# Altium schematic sheet Base_USB_Camera.SchDoc
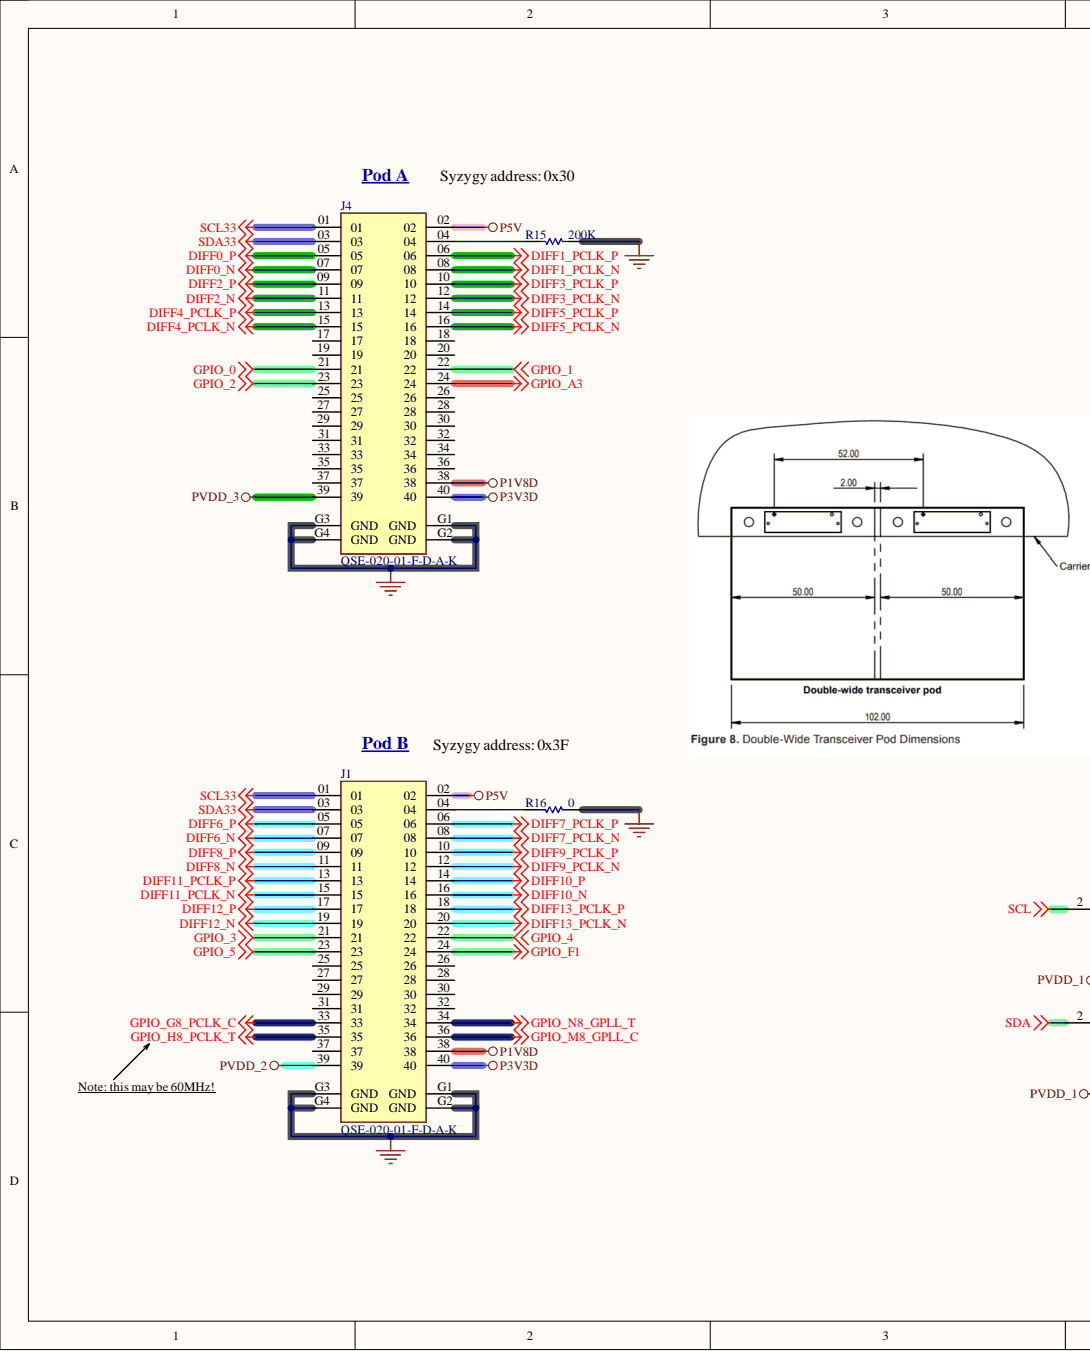
[diagram p0: Base_USB_Camera.SchDoc, left]
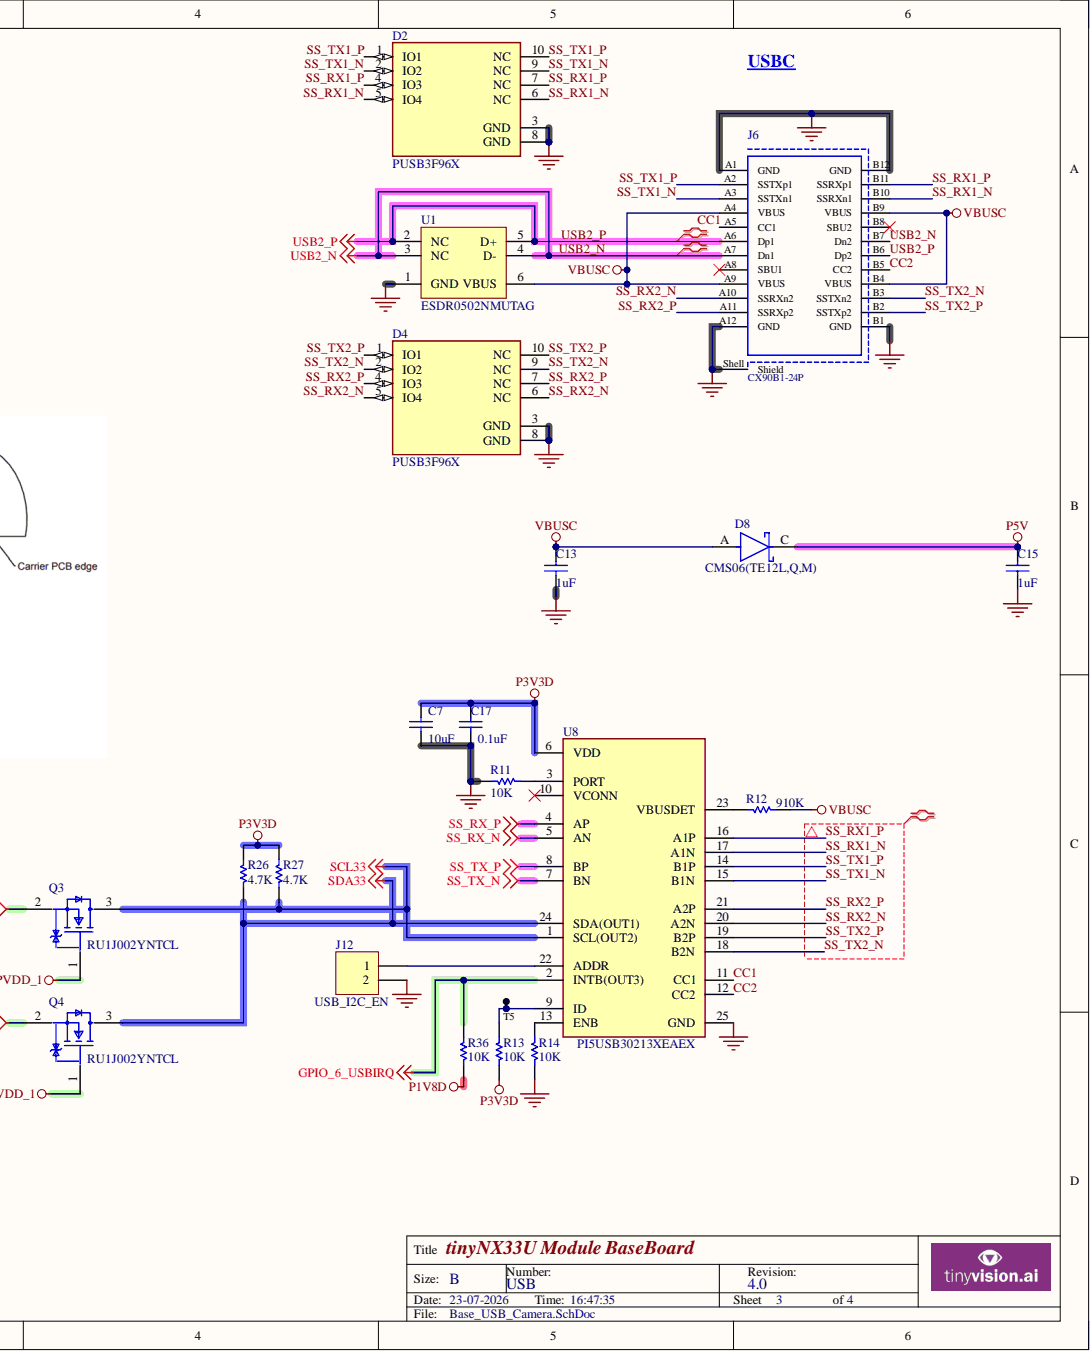
[diagram p1: Base_USB_Camera.SchDoc, right]

## Parts
C7  10uF  [CAPC1005X55USR]
C13  1uF  [CAPC1005X55USR]
C15  1uF  [CAPC1005X55USR]
C17  0.1uF  [CAPC1005X55USR]
D2  PUSB3F96X  [DFN2510A-10]
D4  PUSB3F96X  [DFN2510A-10]
D8  CMS06(TE12L,Q,M)  [SOD-128]
J1  QSE-020-01-F-D-A-K  [SAMTEC_QSE-020-01-XXX-D-A-L]
J4  QSE-020-01-F-D-A-K  [SAMTEC_QSE-020-01-XXX-D-A-L]
J6  CX90B1-24P  [HRS_CX90B1-24P]
J12  Content: USB_I2C_EN  [HDR2X1_MALE_2.54MM]  (hidden comment: Header 2X1_2.54MM)
Q3  RU1J002YNTCL  [FP-UMT3F-MFG]
Q4  RU1J002YNTCL  [FP-UMT3F-MFG]
R11  10K  [RESC1005X40USR]
R12  910K  [RESC1005X40USR]
R13  10K  [RESC1005X40USR]
R14  10K  [RESC1005X40USR]
R15  200K  [RESC1005X40USR]
R16  0  [RESC1005X40USR]
R26  4.7K  [RESC1005X40USR]
R27  4.7K  [RESC1005X40USR]
R36  10K  [RESC1005X40USR]
T5  TP_S  [TP_TH]  (value not drawn)  (no BOM)
U1  ESDR0502NMUTAG  [ONSC-UDFN-6-517AA-01_V]
U8  PI5USB30213XEAEX  [QFN40P400X200X50-25N]  (value not drawn)

## Nets
DIFF0_N: J4.07
DIFF0_P: J4.05
DIFF1_PCLK_N: J4.08
DIFF1_PCLK_P: J4.06
DIFF2_N: J4.11
DIFF2_P: J4.09
DIFF3_PCLK_N: J4.12
DIFF3_PCLK_P: J4.10
DIFF4_PCLK_N: J4.15
DIFF4_PCLK_P: J4.13
DIFF5_PCLK_N: J4.16
DIFF5_PCLK_P: J4.14
DIFF6_N: J1.07
DIFF6_P: J1.05
DIFF7_PCLK_N: J1.08
DIFF7_PCLK_P: J1.06
DIFF8_N: J1.11
DIFF8_P: J1.09
DIFF9_PCLK_N: J1.12
DIFF9_PCLK_P: J1.10
DIFF10_N: J1.16
DIFF10_P: J1.14
DIFF11_PCLK_N: J1.15
DIFF11_PCLK_P: J1.13
DIFF12_N: J1.19
DIFF12_P: J1.17
DIFF13_PCLK_N: J1.20
DIFF13_PCLK_P: J1.18
GND: C7.1 C13.1 C15.1 C17.1 D2.3(GND) D2.8(GND) D4.3(GND) D4.8(GND) J1.G1(GND) J1.G2(GND) J1.G3(GND) J1.G4(GND) J4.G1(GND) J4.G2(GND) J4.G3(GND) J4.G4(GND) J6.A1(GND) J6.A12(GND) J6.B1(GND) J6.B12(GND) J6.Shell(Shield) J12.2 R11.1 R14.2 R15.1 R16.1 U1.1(GND) U8.25(GND)
GPIO_0: J4.21
GPIO_1: J4.22
GPIO_2: J4.23
GPIO_3: J1.21
GPIO_4: J1.22
GPIO_5: J1.23
GPIO_6_USBIRQ: R36.2 U8.2(INTB(OUT3))
GPIO_A3: J4.24
GPIO_F1: J1.24
GPIO_G8_PCLK_C: J1.33
GPIO_H8_PCLK_T: J1.35
GPIO_M8_GPLL_C: J1.36
GPIO_N8_GPLL_T: J1.34
P1V8D: J1.38 J4.38 R36.1
P3V3D: C7.2 C17.2 J1.40 J4.40 R13.1 R26.1 R27.1 U8.6(VDD)
P5V: C15.2 D8.C J1.02 J4.02
PVDD_1: Q3.1 Q4.1
PVDD_2: J1.39
PVDD_3: J4.39
SCL: Q3.2
SCL33: J1.01 J4.01 Q3.3 R27.2 U8.1(SCL(OUT2))
SDA: Q4.2
SDA33: J1.03 J4.03 Q4.3 R26.2 U8.24(SDA(OUT1))
SS_RX_N: U8.5(AN)
SS_RX_P: U8.4(AP)
SS_TX_N: U8.7(BN)
SS_TX_P: U8.8(BP)
VBUSC: C13.2 D8.A J6.A4(VBUS) J6.A9(VBUS) J6.B4(VBUS) J6.B9(VBUS) R12.2 U1.6(VBUS)
CC1: J6.A5(CC1) U8.11(CC1)
CC2: J6.B5(CC2) U8.12(CC2)
SS_RX1_N: D2.5(IO4) D2.6(NC) J6.B10(SSRXn1) U8.17(A1N)
SS_RX1_P: D2.4(IO3) D2.7(NC) J6.B11(SSRXp1) U8.16(A1P)
SS_RX2_N: D4.5(IO4) D4.6(NC) J6.A10(SSRXn2) U8.20(A2N)
SS_RX2_P: D4.4(IO3) D4.7(NC) J6.A11(SSRXp2) U8.21(A2P)
SS_TX1_N: D2.2(IO2) D2.9(NC) J6.A3(SSTXn1) U8.15(B1N)
SS_TX1_P: D2.1(IO1) D2.10(NC) J6.A2(SSTXp1) U8.14(B1P)
SS_TX2_N: D4.2(IO2) D4.9(NC) J6.B3(SSTXn2) U8.18(B2N)
SS_TX2_P: D4.1(IO1) D4.10(NC) J6.B2(SSTXp2) U8.19(B2P)
USB2_N: J6.A7(Dn1) J6.B7(Dn2) U1.3(NC) U1.4(D-)
USB2_P: J6.A6(Dp1) J6.B6(Dp2) U1.2(NC) U1.5(D+)
NetJ1_04: J1.04 R16.2
NetJ4_04: J4.04 R15.2
NetJ12_1: J12.1 U8.22(ADDR)
NetR11_2: R11.2 U8.3(PORT)
NetR12_1: R12.1 U8.23(VBUSDET)
NetR13_2: R13.2 T5.1 U8.9(ID)
NetR14_1: R14.1 U8.13(ENB)

Not connected: J1 25 26 27 28 29 30 31 32 37; J4 17 18 19 20 25 26 27 28 29 30 31 32 33 34 35 36 37; J6 A8(SBU1) B8(SBU2); U8 10(VCONN)

## Library data of the parts
C7 = Cap_10uF_X5R_20%_10V_0402: CAP CER 10UF 10V X5R 0402; Manufacturer: Samsung Electro-Mechanics; Manufacturer Part Number: CL05A106MP5NUNC; Part #: E-CAP-042; Component Kind: Standard; ComponentLink1Description: New link; Height: 0.55mm; Package: 0402; Supplier 1: Digi-Key; Supplier Part Number 1: 1276-1450-1-ND; value: 10uF; Library Name: tinyCLUNX33_Compute.SCHLIB
C13 C15 = CAP CER 1UF 25V X5R 0402: Manufacturer: Murata Electronics; Manufacturer Part Number: GRM155R61E105MA12D; Part #: E-CAP-042; Component Kind: Standard; ComponentLink1Description: New link; Description: CAP CER 1UF 25V X5R 0402; Height: 0.55mm; Package: 0402; Supplier 1: Digi-Key; Supplier Part Number 1: 490-10018-1-ND; value: 1uF; Library Name: Tiger_Ti180.SCHLIB
C17 = CAP CER 0.1UF 16V X7R 0402: Manufacturer: TDK Corporation; Manufacturer Part Number: CGA2B1X7R1C104K050BC; Component Kind: Standard; Description: CAP CER 0.1UF 16V X7R 0402; Height: 0.55mm; Library Name: NX Module baseboard.SCHLIB; Package: 0402; Supplier 1: Digi-Key; Supplier Part Number 1: 445-5613-2-ND; value: 0.1uF
D2 D4 = PUSB3F96X: TVS DIODE 5.5VWM 4.6VC DFN2510A; Manufacturer: Nexperia USA Inc.; Interface: USB; Component Kind: Standard; Manufacturer Part Number: PUSB3F96X; Supplier 1: Digi-Key; Supplier Part Number 1: 1727-2030-1-ND; Library Name: Tiger_Ti180.SCHLIB
D8 = D_CMS06(TE12L,Q,M): Rectifier Diode Schottky 30V 2A 2-Pin M-FLAT T/R; Manufacturer: Toshiba; Element Configuration: Single; Forward Voltage: 370mV; Max Reverse Current: 3mA; Max Surge Current: 40A; Average Rectified Current: 2A; Category: Rectifier Diodes; Component Kind: Standard; ComponentLink1Description: https://datasheet.ciiva.com/916/dst-cms06-tde-en-1613-916722.pdf?src-supplier=Digi-Key; ComponentLink1URL: https://datasheet.ciiva.com/916/dst-cms06-tde-en-1613-916722.pdf?src-supplier=Digi-Key; ComponentLink2Description: https://datasheet.ciiva.com/916/dst-cms06-tde-en-1613-916723.pdf?src-supplier=Digi-Key; ComponentLink2URL: https://datasheet.ciiva.com/916/dst-cms06-tde-en-1613-916723.pdf?src-supplier=Digi-Key; ComponentLink3Description: https://datasheet.ciiva.com/8281/cms06-datasheet-en-20131101-8281819.pdf?src-supplier=Avnet; ComponentLink3URL: https://datasheet.ciiva.com/8281/cms06-datasheet-en-20131101-8281819.pdf?src-supplier=Avnet; Contact Plating: Tin,Silver; Forward Current: 2A; Manufacturer Part Number: CMS06(TE12L,Q,M); Max Operating Temperature: 125°C; Max Repetitive Reverse Voltage (Vrrm): 30V; Max Reverse Leakage Current: 3mA; Max Reverse Voltage (DC): 30V; Min Operating Temperature: -40°C; Mount: Surface Mount; Number of Pins: 2; Package Quantity: 1; Packaging: Tape and Reel; Peak Non-Repetitive Surge Current: 40A; Pricing 1: 1=0.56000, 10=0.48200, 100=0.35950, 500=0.28248, 1000=0.21828 (USD); Radiation Hardening: No; RoHS: No; RoHS Compliant: No; Series: -; Stock 1: 49976; Supplier 1: Digi-Key; Supplier Part Number 1: CMS06QMCT-ND; Library Name: Tiger_Ti180.SCHLIB
J1 J4 = QSE-020-01-F-D-A-K: Q Strip® High-Speed Ground Plane Header, 0.80mm Pitch; Manufacturer: Samtec; Manufacturer Part Number: QTE-020-01-F-D-A; Gender: Male; Mated Stacking Heights: 5mm; Number of Contacts: 40; Pitch: 0.031inch; Category: Board to Board Connectors; Component Kind: Standard; ComponentLink1Description: https://datasheet.ciiva.com/6924/qte-xxx-xx-x-d-xxx-mkt-6924765.pdf?src-supplier=Digi-Key; ComponentLink1URL: https://datasheet.ciiva.com/6924/qte-xxx-xx-x-d-xxx-mkt-6924765.pdf?src-supplier=Digi-Key; Contact Plating: Gold; Library Name: NX Module baseboard.SchLib; Mounting Technology: Surface Mount; Number of Positions: 40; Number of Rows: 2; Package Quantity: 1; Packaging: Tray; Pricing: 1=9.48000, 10=8.53000, 25=8.03560, 40=7.82950, 96=7.62344, 288=6.79931, 480=6.38725, 960=5.64550 (USD); RoHS: Yes; RoHS Compliant: Yes; Series: QStrip® QTE; Stock: 1329; Supplier 1: Digi-Key; Supplier Part Number 1: SAM8846-ND; Termination: Solder
J6 = USB 3.1_HRS_CX90B1-24P: CONN RCP USB3.1 TYPEC 24P SMD RA; Manufacturer: Hirose Electric Co Ltd; Number of Contacts: 24; Component Kind: Standard; ComponentLink1Description: https://www.mouser.com/ds/2/445/632723x00011-782157.pdf?src-supplier=Mouser; ComponentLink1URL: https://www.mouser.com/ds/2/445/632723x00011-782157.pdf?src-supplier=Mouser; ComponentLink2Description: https://katalog.we-online.de/em/datasheet/632723x00011.pdf?src-supplier=Digi-Key; ComponentLink2URL: https://katalog.we-online.de/em/datasheet/632723x00011.pdf?src-supplier=Digi-Key; Manufacturer Part Number: CX90B1-24P; Supplier 1: Digi-Key; Supplier Part Number 1: H125778CT-ND; Library Name: Tiger_Ti180.SCHLIB
J12 = Header 2X1_2.54MM: Conn Header Vert 2POS 2.54MM; Manufacturer: Sullins; Manufacturer Part Number: PRPC002SAAN-RC; Number of Positions: 2; Pitch: 0.1inch; Category: Connectors; Color: Black; Component Kind: Standard; ComponentLink1Description: https://datasheet.ciiva.com/741/xrxczzzsxxn-rc-st-11635-b-741243.pdf?src-supplier=Digi-Key; ComponentLink1URL: https://datasheet.ciiva.com/741/xrxczzzsxxn-rc-st-11635-b-741243.pdf?src-supplier=Digi-Key; Contact Mating Length: 0.23inch; Contact Plating: Gold; Contact Type: Male Pin; Library Name: NX Module baseboard.SCHLIB; Mounting Technology: Through Hole; Number of Rows: 1; Package Quantity: 1; Packaging: Bulk; Pricing: 1=0.08000, 10=0.07300, 25=0.05400, 50=0.04640, 100=0.04490, 250=0.03872, 500=0.03716 (USD); RoHS: Yes; RoHS Compliant: Yes; Series: -; Stock: 31528; Supplier 1: Digi-Key; Supplier Part Number 1: S1011EC-02-ND; Termination: Solder
Q3 Q4 = 1926738d861a973c8d722c53ec8fd09: MOSFET N-CH 50V 0.2A UMT3F; Manufacturer: Rohm; Continuous Drain Current: 200mA; Depletion Mode: False; Drain To Source Resistance: 1.6Ω; Gate To Source Voltage: 8V; Input Capacitace At Vds: 26pF @ 10V; Max Forward Diode Voltage: 1.2V; Max Junction Temp: +150°C; Number Of Channels: 1; Power Dissipation: 150mW; Pulse Drain Current: 800mA; Rthja Max: 833°C/W; Standoff Height: 0mm; Threshold Vgs Max: 0.8V; Threshold Vgs Min: 0.3V; Turn Off Delay Time: 17ns; Turn On Delay Time: 5ns; Automotive: No; Category: Trans; Component Kind: Standard; ComponentLink2Description: Datasheet; ComponentLink2URL: https://d1d2qsbl8m0m72.cloudfront.net/en/products/databook/datasheet/discrete/transistor/mosfet/ru1j002yn-e.pdf; Device Class L1: Discrete Semiconductors; Device Class L2: Transistors; Device Class L3: MOSFETs; Digikey Description: MOSFET N-CH 50V 0.2A UMT3F; Drain To Source Breakdown Voltage: 50V; Drain To Source Voltage: 50V; Height: 1.05mm; Lead Free: Yes; Library Name: rpi_adapter.SCHLIB; Manufacturer Part Number: RU1J002YNTCL; Mouser Description: MOSFET 0.9V Drive Nch MOSFET; Number Of N Channels: 1; Octopart: https://octopart.com/ru1j002yntcl-rohm-47197697; Package: UMT3F; RoHS: TRUE; Temperature: to +150°C; Temperature Range High: +150°C
R11 R13 R14 R36 = RES SMD 10K OHM 1% 1/16W 0402: Manufacturer: Samsung Electro-Mechanics; Manufacturer Part Number: RC1005F103CS; Component Kind: Standard; Description: RES SMD 10K OHM 1% 1/16W 0402; Height: 0.4mm; Library Name: NX Module baseboard.SCHLIB; Package: 0402; Supplier 1: Digi-Key; Supplier Part Number 1: 1276-3431-2-ND; value: 10K
R12 = RES 910K OHM 1% 1/16W 0402: Manufacturer: YAGEO; Manufacturer Part Number: RC0402FR-07910KL; Component Kind: Standard; Description: RES 910K OHM 1% 1/16W 0402; Height: 0.4mm; Library Name: NX Module baseboard.SCHLIB; Package: 0402; Supplier 1: Digi-Key; Supplier Part Number 1: YAG3257CT-ND; value: 910K
R15 = Res_10K_1%_0402: RES SMD 200K OHM 1% 1/16W 0402; Manufacturer: Stackpole Electronics Inc; Manufacturer Part Number: RMCF0402FT200K; Component Kind: Standard; Height: 0.4mm; Package: 0402; Supplier 1: Digi-Key; Supplier Part Number 1: RMCF0402FT200KTR-ND; value: 200K; Library Name: MCD_Temp_LBE.SchLib
R16 = RES 0 OHM JUMPER 1/20W 0201: RES 0 OHM JUMPER 1/16W 0402; Manufacturer: Yageo; Manufacturer Part Number: RC0402JR-070RL; Component Kind: Standard; Height: 0.4mm; Library Name: Smart Camera v2.SCHLIB; Package: 0402; Supplier 1: Digi-Key; Supplier Part Number 1: 311-0.0JRCT-ND; value: 0
R26 R27 = RES 4.7K OHM 1% 1/16W 0402: RES SMD 4.7K OHM 1% 1/16W 0402; Manufacturer: Stackpole Electronics Inc; Manufacturer Part Number: RMCF0402FT4K70; Component Kind: Standard; Height: 0.4mm; Package: 0402; Supplier 1: Digi-Key; Supplier Part Number 1: RMCF0402FT4K70TR-ND; value: 4.7K; Library Name: Tiger_Ti180.SCHLIB
T5 = TP_S: test point; Library Name: AiC.SCHLIB
U1 = ONSC-ESDR0502N-UDFN-6-517AA-01: TVS DIODE 5.5VWM 6UDFN; Manufacturer: ON Semiconductor; Component Kind: Standard; ComponentLink1Description: Manufacturer URL; ComponentLink1URL: http://www.onsemi.com/; ComponentLink2Description: Datasheet; ComponentLink2URL: http://www.onsemi.cn/pub_link/Collateral/ESDR0502N-D.PDF; ComponentLink3Description: Package Specification; ComponentLink3URL: http://www.onsemi.com/pub_link/Collateral/517AA.PDF; Manufacturer Part Number: ESDR0502NMUTAG; Supplier 1: Mouser; Supplier Part Number 1: 863-ESDR0502NMUTAG; Library Name: Tiger_Ti180.SCHLIB
U8 = PI5USB30213XEAEX: USB Power SW DIST Single 2.7V to 5.5V 1.5A/3A 24-Pin TQFN T/R; Manufacturer: Diodes; Category: Power Management ICs; Component Kind: Standard; ComponentLink1Description: https://media.digikey.com/pdf/Data%20Sheets/Pericom%20PDFs/PI5USB30213_Web.pdf?src-supplier=Digi-Key; ComponentLink1URL: https://media.digikey.com/pdf/Data%20Sheets/Pericom%20PDFs/PI5USB30213_Web.pdf?src-supplier=Digi-Key; Library Name: NX Module baseboard.SCHLIB; Manufacturer Part Number: PI5USB30213XEAEX; Pricing: 1=1.92000, 10=1.72200, 25=1.62480, 100=1.38450, 250=1.30000, 500=1.13750, 1000=0.94250 (USD); RoHS: Yes; RoHS Compliant: Yes; Stock: 3456; Supplier 1: Digi-Key; Supplier Part Number 1: PI5USB30213XEAEXCT-ND
